# Altium schematic sheet Power.SchDoc(Power)
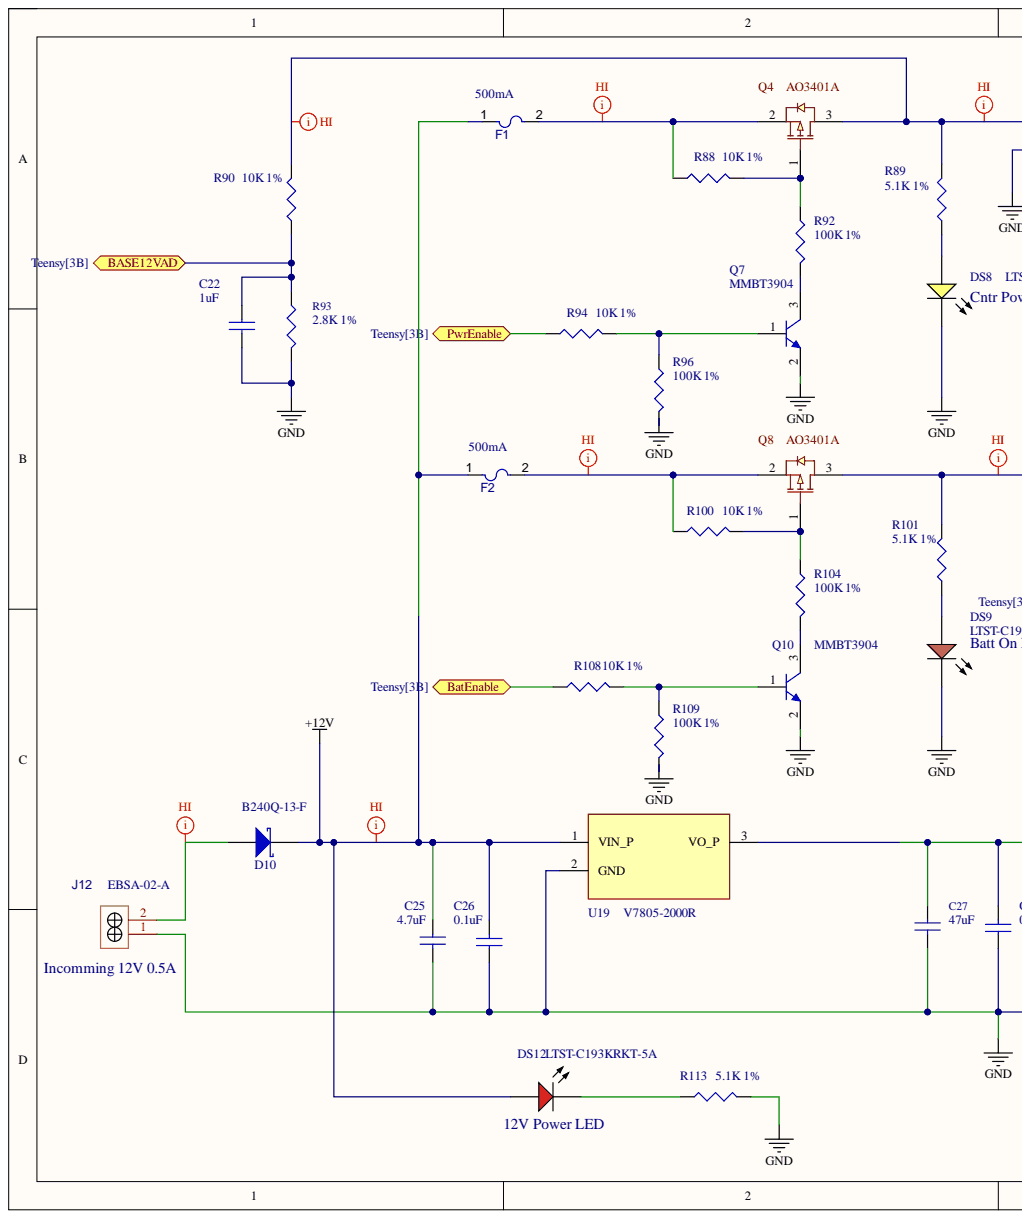
[diagram p0: Power.SchDoc, left]
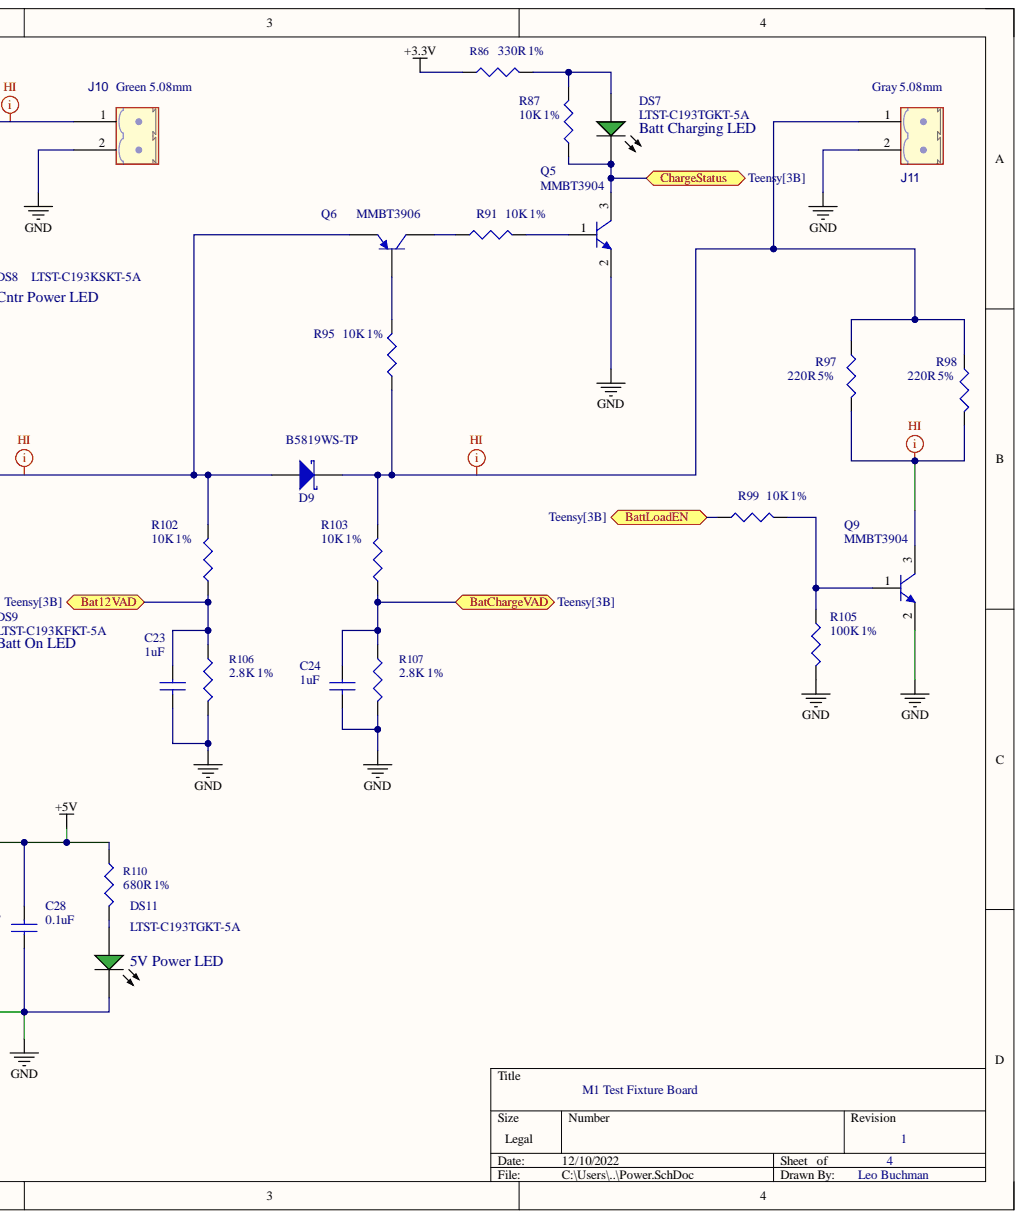
[diagram p1: Power.SchDoc, right]

## Parts
C22  1uF  [C0402]
C23  1uF  [C0402]
C24  1uF  [C0402]
C25  4.7uF  [C0603]
C26  0.1uF  [C0402]
C27  47uF  [C1210]
C28  0.1uF  [C0402]
D9  B5819WS-TP  [MCC-SOD123_V]
D10  B240Q-13-F  [DIODE_DO214AA]
DS7  LTST-C193TGKT-5A  [LED-GREEN_0603]
DS8  LTST-C193KSKT-5A  [LED-YELLOW_0603]
DS9  LTST-C193KFKT-5A  [LED-ORANGE_0603]
DS11  LTST-C193TGKT-5A  Reverse Voltage: 5V  [LED-GREEN_0603]
DS12  LTST-C193KRKT-5A  [LED-RED_0603]
F1  500mA  [FUSE_F050-2]
F2  500mA  [FUSE_F050-2]
J10  Green 5.08mm  Housing Color: Green  [Conn_5433668]
J11  Gray 5.08mm  [Conn_5442002]
J12  EBSA-02-A  [CONN-TH_2P-P3.50_JL15EDGRC-35002G01]
Q4  AO3401A  [AO-SOT-23-3L_V]
Q5  MMBT3904  [sot23-3]
Q6  MMBT3906  [sot23-3]
Q7  MMBT3904  [sot23-3]
Q8  AO3401A  [AO-SOT-23-3L_V]
Q9  MMBT3904  [sot23-3]
Q10  MMBT3904  [sot23-3]
R86  330R 1%  Resistance: 330R  Tolerance: 1%  [R0402]
R87  10K 1%  Tolerance: 1%  [R0402]
R88  10K 1%  [R0402]
R89  5.1K 1%  Tolerance: 1%  [R0402]
R90  10K 1%  Tolerance: 1%  [R0402]
R91  10K 1%  Tolerance: 1%  [R0402]
R92  100K 1%  [R0402]
R93  2.8K 1%  [R0402]
R94  10K 1%  Tolerance: 1%  [R0402]
R95  10K 1%  Tolerance: 1%  [R0402]
R96  100K 1%  [R0402]
R97  220R 5%  Tolerance: 5%  [RESC6331X75N]
R98  220R 5%  Resistance: 220R  Tolerance: 5%  [RESC6331X75N]
R99  10K 1%  Tolerance: 1%  [R0402]
R100  10K 1%  [R0402]
R101  5.1K 1%  Tolerance: 1%  [R0402]
R102  10K 1%  Tolerance: 1%  [R0402]
R103  10K 1%  Tolerance: 1%  [R0402]
R104  100K 1%  [R0402]
R105  100K 1%  [R0402]
R106  2.8K 1%  [R0402]
R107  2.8K 1%  [R0402]
R108  10K 1%  Tolerance: 1%  [R0402]
R109  100K 1%  [R0402]
R110  680R 1%  Resistance: 680R  [R0402]
R113  5.1K 1%  Tolerance: 1%  [R0402]
U19  V7805-2000R  [CONV_V7805-2000R]

## Ports
BASE12VAD, Bat12VAD, BatChargeVAD, BatEnable, BattLoadEN, ChargeStatus, PwrEnable

## Nets
+3.3V: R86.1
+5V: C27.1 C28.2 R110.1 U19.3
+12V: C25.1 C26.2 D10.2 DS12.1 F1.1 F2.1 U19.1
GND: C22.2 C23.2 C24.2 C25.2 C26.1 C27.2 C28.1 DS8.2 DS9.2 DS11.2 J10.2 J11.2 J12.1 Q5.2 Q7.2 Q9.2 Q10.2 R93.2 R96.2 R105.2 R106.2 R107.2 R109.2 R113.2 U19.2
BASE12VAD: C22.1 R90.1 R93.1 ; port BASE12VAD
Bat12VAD: C23.1 R102.1 R106.1 ; port Bat12VAD
BatChargeVAD: C24.1 R103.1 R107.1 ; port BatChargeVAD
BatEnable: R108.1 ; port BatEnable
BattLoadEN: R99.1 ; port BattLoadEN
ChargeStatus: DS7.2 Q5.3 R87.1 ; port ChargeStatus
PwrEnable: R94.1 ; port PwrEnable
NetD9_1: D9.1 Q6.2 Q8.3 R101.2 R102.2
NetD9_2: D9.2 J11.1 R95.1 R97.1 R98.1 R103.2
NetD10_1: D10.1 J12.2
NetDS7_1: DS7.1 R86.2 R87.2
NetDS8_1: DS8.1 R89.1
NetDS9_1: DS9.1 R101.1
NetDS11_1: DS11.1 R110.2
NetDS12_2: DS12.2 R113.1
NetF1_2: F1.2 Q4.2 R88.1
NetF2_2: F2.2 Q8.2 R100.1
NetJ10_1: J10.1 Q4.3 R89.2 R90.2
NetQ4_1: Q4.1 R88.2 R92.1
NetQ5_1: Q5.1 R91.2
NetQ6_1: Q6.1 R95.2
NetQ6_3: Q6.3 R91.1
NetQ7_1: Q7.1 R94.2 R96.1
NetQ7_3: Q7.3 R92.2
NetQ8_1: Q8.1 R100.2 R104.1
NetQ9_1: Q9.1 R99.2 R105.1
NetQ9_3: Q9.3 R97.2 R98.2
NetQ10_1: Q10.1 R108.2 R109.1
NetQ10_3: Q10.3 R104.2

## Library data of the parts
C22-C24 = Cap-00003: CAP CER 1UF 10V Y5V 0402; Manufacturer: KEMET; Manufacturer Part Number: C0402C105Z8VAC7867; Database Table Name: Capacitors; Dielectric Material: Ceramic; Tolerance: 80%; Capacitance: 1uF; Case Code (Imperial): 0402; Case Code (Metric): 1005; Case/Package: 0402; Category: Ceramic Capacitors; Component Kind: Standard; ComponentLink1Description: https://api.kemet.com/component-edge/download; ComponentLink1URL: https://api.kemet.com/component-edge/download; LCSC Part Number: C52923; Max Operating Temperature: 85°C; Min Operating Temperature: -30°C; Mount: Surface Mount; Number of Pins: 2; Packaging: Cut Tape; Part Name: Cap-00003; Pricing 1: 1=0.28000, 10=0.18900, 50=0.12580, 100=0.1070; RoHS: Yes; Stock 1: 9702; Supplier 1: Digi-Key; Supplier Part Number 1: 399-14873-1-ND; Voltage Rating: 10V; Library Name: Leo-Home-SQL-Lib.DbLib
C25 = Cap-00001: CAP CER 4.7UF 16V X5R 0603; Manufacturer: Samsung; Manufacturer Part Number: CL10A475KO8NNNC; Database Table Name: Capacitors; Dielectric Material: Ceramic; Tolerance: 10%; Capacitance: 4.7uF; Case Code (Imperial): 0603; Case Code (Metric): 1608; Case/Package: 0603; Category: Ceramic Capacitors; Component Kind: Standard; ComponentLink1Description: https://datasheet.ciiva.com/25795/cl10a475ko8; ComponentLink1URL: https://datasheet.ciiva.com/25795/cl10a475ko8; ComponentLink2Description: https://datasheet.ciiva.com/26838/getdatashee; ComponentLink2URL: https://datasheet.ciiva.com/26838/getdatashee; ComponentLink3Description: https://datasheet.ciiva.com/29198/457663-da-0; ComponentLink3URL: https://datasheet.ciiva.com/29198/457663-da-0; Construction: Flat; Contact Plating: Tin; Depth: 0.8mm; Dielectric: X5R; Height: 0.8mm; LCSC Part Number: C19666; Length: 1.6mm; Material: Ceramic; Max Operating Temperature: 85°C; Min Operating Temperature: -55°C; Mount: Surface Mount; Number of Pins: 2; Package Quantity: 1; Packaging: Tape and Reel; Part Name: Cap-00001; Pricing 1: 1=0.13000, 10=0.09100, 50=0.05180, 100=0.0429; Radiation Hardening: No; RoHS: Yes; RoHS Compliant: Yes; Series: CL; Stock 1: 1593998; Supplier 1: Digi-Key; Supplier Part Number 1: 1276-1784-1-ND; Thickness: 0.035inch; Voltage: 16V; Voltage Rating: 16V; Width: 0.031inch; Library Name: Leo-Home-SQL-Lib.DbLib
C26 C28 = Cap-00005: Cap Ceramic 0.1uF 50V X7R 10% Pad SMD 0402 125C Automotive T/R; Manufacturer: Murata; Manufacturer Part Number: GRT155R71H104KE01D; Database Table Name: Capacitors; Case Code (Imperial): 0402; Case Code (Metric): 1005; Case/Package: 0402; Category: Ceramic Capacitors; Component Kind: Standard; Dielectric Material: Ceramic; LCSC Part Number: C307331; Mount: Surface Mount; Number of Pins: 2; Part Name: Cap-00005; Pricing 1: 1=0.10000, 10=0.04700, 50=0.02580, 100=0.0211; RoHS: Yes; RoHS Compliant: Yes; Stock 1: 179948; Supplier 1: Digi-Key; Supplier Part Number 1: 490-GRT155R71H104KE01DCT-ND; Voltage Rating (DC): 50V; Library Name: Leo-Home-SQL-Lib.DbLib
C27 = Cap-00004: CAP CER 47UF 16V X5R 1210; Manufacturer: Murata; Manufacturer Part Number: GRM32ER61C476ME15L; Database Table Name: Capacitors; Dielectric Material: Ceramic; Tolerance: 20%; Capacitance: 47uF; Case Code (Imperial): 1210; Case Code (Metric): 3225; Case/Package: 1210; Category: Ceramic Capacitors; Component Kind: Standard; ComponentLink1Description: https://datasheet.ciiva.com/141/product-14197; ComponentLink1URL: https://datasheet.ciiva.com/141/product-14197; ComponentLink2Description: https://datasheet.ciiva.com/14116/grm32er61c4; ComponentLink2URL: https://datasheet.ciiva.com/14116/grm32er61c4; ComponentLink3Description: https://datasheet.ciiva.com/7719/415303-77191; ComponentLink3URL: https://datasheet.ciiva.com/7719/415303-77191; ComponentLink4Description: https://datasheet.ciiva.com/141/partnumbering; ComponentLink4URL: https://datasheet.ciiva.com/141/partnumbering; ComponentLink5Description: https://datasheet.ciiva.com/141/partnumbering; ComponentLink5URL: https://datasheet.ciiva.com/141/partnumbering; ComponentLink6Description: https://datasheet.ciiva.com/16343/c02e-163436; ComponentLink6URL: https://datasheet.ciiva.com/16343/c02e-163436; Depth: 2.5mm; Dielectric: X5R; Height: 2.5mm; LCSC Part Number: C77101; Lead Free: Lead Free; Length: 3.2mm; Max Operating Temperature: 85°C; Min Operating Temperature: -55°C; Mount: Surface Mount; Number of Pins: 2; Package Quantity: 1000; Packaging: Tape and Reel; Part Name: Cap-00004; Pricing 1: 1=0.73000, 10=0.53900, 50=0.43120, 100=0.3697; REACH SVHC: No SVHC; RoHS: Yes; RoHS Compliant: Yes; Series: GRM; Stock 1: 238447; Supplier 1: Digi-Key; Supplier Part Number 1: 490-3888-1-ND; Termination: SMD/SMT; Thickness: 0.106inch; Voltage: 16V; Voltage Rating: 16V; Voltage Rating (DC): 16V; Width: 0.098inch; Library Name: Leo-Home-SQL-Lib.DbLib
D9 = Diode-0002: DIODE SCHOTTKY 40V 1A SOD323; Manufacturer: MCC or Jiangsu Changjing Elec; Manufacturer Part Number: B5819W TP; Color: Blue; Database Table Name: diodes; Dominant Wavelength: 470nm; Forward Current: 25mA; Forward Voltage: 600mV @ 1A; Lens Style: Diffused,Colorless,Rectangular,Clear; Luminous Intensity: 28.5mcd; Number of Elements: 1; Power Dissipation: 110W; Reverse Voltage: 5V; Test Current: 20mA; Viewing Angle: 120°; Average Rectified Current: 1A; Case Code (Imperial): sod123; Case/Package: sod232; Category: Rectifier Diodes; Component Kind: Standard; Component Type: Diodes; ComponentLink1Description: https://datasheet.ciiva.com/16302/19-217-bhc-; ComponentLink1URL: https://datasheet.ciiva.com/16302/19-217-bhc-; ComponentLink2Description: https://datasheet.ciiva.com/26901/getdatashee; ComponentLink2URL: https://datasheet.ciiva.com/26901/getdatashee; Datasheet URL: http://www.mccsemi.com/up_pdf/B5817W-B5819W(SOD-123).pdf; Datasheet Version: Rev. B, 01/2013; Depth: 0.8mm; LCSC Part Number: C84354; Lead Free: Lead Free; Length: 1.6mm; Manufacturer URL: http://www.mccsemi.com/; Max Operating Temperature: 85°C; Max Reverse Voltage (DC): 40V; Min Operating Temperature: -40°C; Mount: Surface Mount; Mounting Technology: SM; Number of LEDs: 1; Package Description: 2-Pin Small Outline Diode, Body 2.7 x 1.6 mm, Height 1.35 mm; Package Reference: SOD123; Package URL: http://www.mccsemi.com/up_pdf/1N4448W(SOD-123).pdf; Packaging: Tape and Reel; Part Name: Diode-0002; Pricing 1: 1=0.47000, 10=0.35200, 100=0.19930, 500=0.132; Radiation Hardening: No; RoHS: Yes; RoHS Compliant: Yes; Stock 1: 237825; Supplier 1: Digi-Key; Supplier Part Number 1: 5819W-TPMSTR-ND; Library Name: Leo-Home-SQL-Lib.DbLib
D10 = Diode-0008: Rectifier Diode Schottky 40V 2A Automotive 2-Pin SMB T/R; Average Rectified Current: 200mA; Capacitance: 10pF; Database Table Name: Diodes; Forward Voltage: 800mV; Max Forward Surge Current (Ifsm): 600mA; Max Power Dissipation: 200mW; Max Repetitive Reverse Voltage (Vrrm): 30V; Max Reverse Current: 2uA; Reverse Recovery Time: 5ns; Case/Package: SMB; Category: Rectifier Diodes; Component Kind: Standard; Component Type: Diodes; ComponentLink1Description: https://datasheet.ciiva.com/34302/1539235-343; ComponentLink1URL: https://datasheet.ciiva.com/34302/1539235-343; ComponentLink2Description: https://datasheet.ciiva.com/22695/1911820-226; ComponentLink2URL: https://datasheet.ciiva.com/22695/1911820-226; ComponentLink3Description: https://datasheet.ciiva.com/15144/getdatashee; ComponentLink3URL: https://datasheet.ciiva.com/15144/getdatashee; Contact Plating: Tin,Matte; Current Rating: 200mA; Datasheet URL: http://www.mccsemi.com/up_pdf/B5817W-B5819W(SOD-123).pdf; Datasheet Version: Rev. B, 01/2013; Forward Current: 200mA; Height: 1mm; LCSC Part Number: C460673; Lead Free: Lead Free; Length: 2.9mm; Manufacturer: Diodes; Manufacturer Part Number: B240Q-13-F; Manufacturer URL: http://www.mccsemi.com/; Max Operating Temperature: 150°C; Max Reverse Voltage (DC): 30V; Min Operating Temperature: -65°C; Mount: Surface Mount; Mounting Technology: SM; Number of Pins: 2; Package Description: 2-Pin Small Outline Diode, Body 2.7 x 1.6 mm, Height 1.35 mm; Package Quantity: 3000; Package Reference: SOD123; Package URL: http://www.mccsemi.com/up_pdf/1N4448W(SOD-123).pdf; Packaging: Tape and Reel; Part Name: Diode-0008; Peak Non-Repetitive Surge Current: 600mA; Power Dissipation: 200mW; Power Rating: 200mW; Pricing 1: 1=0.40000, 10=0.32600, 100=0.22180, 500=0.166; Radiation Hardening: No; REACH SVHC: No SVHC; RoHS: Yes; RoHS Compliant: Yes; Series: -; Stock 1: 9228; Supplier 1: Digi-Key; Supplier Part Number 1: B240Q-13-FDICT-ND; Termination: SMD/SMT; Voltage Rating (DC): 30V; Weight: 0.000282oz; Width: 1.3mm; Library Name: Leo-Home-SQL-Lib.DbLib
DS7 = Diode-0007: LED Green CLEAR 0603 SMD; Manufacturer: Vishay Lite-On; Access Time: 3500 ns; Average Rectified Current: 2A; Case\Package: SOIC; Clock Frequency: 100 KHz; Database Table Name: Diodes; Density: 32 kb; Dominant Wavelength: 535nm; Element Configuration: Single; Forward Current: 5mA; Forward Voltage: 2.0V; Frequency: 400 KHz; Lens Color: Colorless; Lens Style: Diffused,Colorless,Rectangular; Luminous Intensity: 280mcd; Max Repetitive Reverse Voltage (Vrrm): 40V; Max Reverse Current: 500uA; Max Supply Voltage: 5.5 V; Min Supply Voltage: 1.7 V; Nominal Supply Current: 2 mA; Number of Elements: 1; Peak Non-Repetitive Surge Current: 50A; Power Dissipation: 76mW; Reverse Voltage: 5V; Viewing Angle: 130°; Case Code (Imperial): 0603; Case Code (Metric): 1608; Case/Package: 0603; Category: LEDs; Color: Green; Component Kind: Standard; ComponentLink1Description: https://datasheet.ciiva.com/2111/ltst-c193tgk; ComponentLink1URL: https://datasheet.ciiva.com/2111/ltst-c193tgk; ComponentLink2Description: https://datasheet.ciiva.com/11775/0900766b80d; ComponentLink2URL: https://datasheet.ciiva.com/11775/0900766b80d; ComponentLink3Description: https://datasheet.ciiva.com/4689/lite-on-ltst; ComponentLink3URL: https://datasheet.ciiva.com/4689/lite-on-ltst; ComponentLink4Description: https://datasheet.ciiva.com/2111/p-100-ltst-c; ComponentLink4URL: https://datasheet.ciiva.com/2111/p-100-ltst-c; ComponentLink5Description: https://datasheet.ciiva.com/2111/p-100-ltst-c; ComponentLink5URL: https://datasheet.ciiva.com/2111/p-100-ltst-c; ComponentLink6Description: http://www.mouser.com/ds/2/115/B220AQ_Q-B260A; ComponentLink6URL: http://www.mouser.com/ds/2/115/B220AQ_Q-B260A; ComponentLink7Description: https://www.diodes.com/assets/Datasheets/ds13; ComponentLink7URL: https://www.diodes.com/assets/Datasheets/ds13; ComponentLink8Description: http://www.diodes.com/datasheets/ds13004.pdf?; ComponentLink8URL: http://www.diodes.com/datasheets/ds13004.pdf?; Contact Plating: Tin,Matte; DatasheetVersion: Mar-12; Depth: 0.8mm; Height: 0.35mm; Illumination Color: Green; LCSC Part Number: C72043; Lead Free: Lead Free; Length: 1.6mm; Lens Transparency: Clear; Manufacturer Part Number: LTST-C193TGKT-5A; Max Clock Frequency: 100 KHz; Max Operating Temperature: 80°C; Max Reverse Voltage (DC): 40V; Min Operating Temperature: -20°C; Mount: Surface Mount; Number of LEDs: 1; Number of Pins: 2; Package Quantity: 3000; PackageDescription: 2-Pin Surface Mount Device, Body 1.6 x 0.8 mm, Height 0.9 mm; PackageReference: HSMX-C190; Packaging: Tape and Reel; Part Name: Diode-0007; Pins: 8; Pricing 1: 1=0.45000, 10=0.27400, 100=0.15780, 1000=0.12; Radiation Hardening: No; RoHS: Yes; RoHS Compliant: Yes; RoHSCompliant: true; Series: -; Stock 1: 429188; Supplier 1: Digi-Key; Supplier Part Number 1: 160-1832-1-ND; Test Current: 5mA; Width: 0.8mm; Library Name: Leo-Home-SQL-Lib.DbLib
DS8 = Diode-0006: LED Yellow CLEAR 0603 SMD; Manufacturer: Vishay Lite-On; Case\Package: 0603; Database Table Name: Diodes; Dominant Wavelength: 594.5nm; Forward Current: 30mA; Forward Voltage: 2.0V; Lens Color: Colorless; Lens Style: Diffused,Colorless,Rectangular; Luminous Intensity: 45mcd; Number of Elements: 1; Power Dissipation: 75mW; Resistance: 390 Ohm; Reverse Voltage: 5V; Test Current: 5mA; Viewing Angle: 130°; Voltage Rating: 50 V; Case Code (Imperial): 0603; Case Code (Metric): 1608; Case/Package: 0603; Category: LEDs; Color: Yellow; Component Kind: Standard; ComponentLink1Description: https://datasheet.ciiva.com/11775/0900766b80d; ComponentLink1URL: https://datasheet.ciiva.com/11775/0900766b80d; ComponentLink2Description: https://datasheet.ciiva.com/4688/lite-on-ltst; ComponentLink2URL: https://datasheet.ciiva.com/4688/lite-on-ltst; ComponentLink3Description: https://datasheet.ciiva.com/26890/getdatashee; ComponentLink3URL: https://datasheet.ciiva.com/26890/getdatashee; ComponentLink4Description: https://datasheet.ciiva.com/2110/s-110-ltst-c; ComponentLink4URL: https://datasheet.ciiva.com/2110/s-110-ltst-c; ComponentLink5Description: https://datasheet.ciiva.com/2110/s-110-ltst-c; ComponentLink5URL: https://datasheet.ciiva.com/2110/s-110-ltst-c; ComponentLink6Description: https://datasheet.ciiva.com/2110/s-110-ltst-c; ComponentLink6URL: https://datasheet.ciiva.com/2110/s-110-ltst-c; ComponentLink7Description: https://datasheet.ciiva.com/2110/ltst-c193kfk; ComponentLink7URL: https://datasheet.ciiva.com/2110/ltst-c193kfk; DatasheetVersion: Mar-12; Depth: 0.8mm; Height: 0.35mm; Illumination Color: Yellow; LCSC Part Number: C72038; Lead Free: Lead Free; Length: 1.6mm; Lens Transparency: Clear; Manufacturer Part Number: LTST-C193KSKT-5A; Max Operating Temperature: 85°C; Min Operating Temperature: -55°C; Mount: Surface Mount; Number of LEDs: 1; Number of Pins: 2; Package Quantity: 1; PackageDescription: 2-Pin Surface Mount Device, Body 1.6 x 0.8 mm, Height 0.9 mm; PackageReference: HSMX-C190; Packaging: Tape and Reel; Part Name: Diode-0006; Pins: 2; Power Rating: 75mW; Pricing 1: 1=0.39000, 10=0.20900, 100=0.09720, 500=0.089; Radiation Hardening: No; RoHS: Yes; RoHS Compliant: Yes; RoHSCompliant: true; Stock 1: 147319; Supplier 1: Digi-Key; Supplier Part Number 1: 160-1831-1-ND; Width: 0.8mm; Library Name: Leo-Home-SQL-Lib.DbLib
DS9 = Diode-0004: LED Orange CLEAR 0603 SMD; Manufacturer: Vishay Lite-On; Database Table Name: Diodes; Dominant Wavelength: 605nm; Forward (Drive) Current: 20mA; Forward Current: 5mA; Forward Voltage: 2.0V; Intensity: 22mcd; Lens Color: Colorless; Lens Style: Diffused,Colorless,Rectangular,Clear; Luminous Intensity: 28.1mcd; Number of Elements: 1; Viewing Angle: 130°; Case Code (Imperial): 0603; Case Code (Metric): 1608; Case/Package: 0603; Category: LEDs; Color: Orange; Component Kind: Standard; Datasheet URL: https://datasheet.ciiva.com/11773/0900766b80df3ad8-11773825.pdf; Datasheet Version: Rev. 2.0, 03/2010; Dimension: 1.6x0.8x0.36mm; Height: 0.35mm; Illumination Color: Orange; LCSC Part Number: C434425; Lead Free: Lead Free; Lens Transparency: Clear; Lens Type: Clear; Manufacturer Part Number: LTST-C193KFKT-5A; Manufacturer URL: http://www.rohm.com/web/global/; Max Operating Temperature: 85°C; Min Operating Temperature: -55°C; Mount: Surface Mount; Mounting Technology: SurfaceMount; Number of Pins: 2; Package Description: 2-Pin Surface Mount Device, Body 1.6 x 0.8 mm; Package Reference: 1608; Packaging: Tape and Reel; Part Name: Diode-0004; Pricing 1: 1=0.39000, 10=0.20900, 100=0.09720, 500=0.089; Radiation Hardening: No; RoHS: Yes; RoHS Compliant: Yes; Stock 1: 55639; Supplier 1: Digi-Key; Supplier Part Number 1: 160-1829-1-ND; Width: 0.8mm; Library Name: Leo-Home-SQL-Lib.DbLib
DS11 = Diode-0007: LED Green CLEAR 0603 SMD; Manufacturer: Vishay Lite-On; Access Time: 3500 ns; Average Rectified Current: 2A; Case\Package: SOIC; Clock Frequency: 100 KHz; Database Table Name: Diodes; Density: 32 kb; Dominant Wavelength: 535nm; Element Configuration: Single; Forward Current: 5mA; Forward Voltage: 2.0V; Frequency: 400 KHz; Lens Color: Colorless; Lens Style: Diffused,Colorless,Rectangular; Luminous Intensity: 280mcd; Max Repetitive Reverse Voltage (Vrrm): 40V; Max Reverse Current: 500uA; Max Supply Voltage: 5.5 V; Min Supply Voltage: 1.7 V; Nominal Supply Current: 2 mA; Number of Elements: 1; Peak Non-Repetitive Surge Current: 50A; Power Dissipation: 76mW; Viewing Angle: 130°; Case Code (Imperial): 0603; Case Code (Metric): 1608; Case/Package: 0603; Category: LEDs; Color: Green; Component Kind: Standard; ComponentLink1Description: https://datasheet.ciiva.com/2111/ltst-c193tgk; ComponentLink1URL: https://datasheet.ciiva.com/2111/ltst-c193tgk; ComponentLink2Description: https://datasheet.ciiva.com/11775/0900766b80d; ComponentLink2URL: https://datasheet.ciiva.com/11775/0900766b80d; ComponentLink3Description: https://datasheet.ciiva.com/4689/lite-on-ltst; ComponentLink3URL: https://datasheet.ciiva.com/4689/lite-on-ltst; ComponentLink4Description: https://datasheet.ciiva.com/2111/p-100-ltst-c; ComponentLink4URL: https://datasheet.ciiva.com/2111/p-100-ltst-c; ComponentLink5Description: https://datasheet.ciiva.com/2111/p-100-ltst-c; ComponentLink5URL: https://datasheet.ciiva.com/2111/p-100-ltst-c; ComponentLink6Description: http://www.mouser.com/ds/2/115/B220AQ_Q-B260A; ComponentLink6URL: http://www.mouser.com/ds/2/115/B220AQ_Q-B260A; ComponentLink7Description: https://www.diodes.com/assets/Datasheets/ds13; ComponentLink7URL: https://www.diodes.com/assets/Datasheets/ds13; ComponentLink8Description: http://www.diodes.com/datasheets/ds13004.pdf?; ComponentLink8URL: http://www.diodes.com/datasheets/ds13004.pdf?; Contact Plating: Tin,Matte; DatasheetVersion: Mar-12; Depth: 0.8mm; Height: 0.35mm; Illumination Color: Green; LCSC Part Number: C72043; Lead Free: Lead Free; Length: 1.6mm; Lens Transparency: Clear; Manufacturer Part Number: LTST-C193TGKT-5A; Max Clock Frequency: 100 KHz; Max Operating Temperature: 80°C; Max Reverse Voltage (DC): 40V; Min Operating Temperature: -20°C; Mount: Surface Mount; Number of LEDs: 1; Number of Pins: 2; Package Quantity: 3000; PackageDescription: 2-Pin Surface Mount Device, Body 1.6 x 0.8 mm, Height 0.9 mm; PackageReference: HSMX-C190; Packaging: Tape and Reel; Part Name: Diode-0007; Pins: 8; Pricing 1: 1=0.45000, 10=0.27400, 100=0.15780, 1000=0.12; Radiation Hardening: No; RoHS: Yes; RoHS Compliant: Yes; RoHSCompliant: true; Series: -; Stock 1: 429188; Supplier 1: Digi-Key; Supplier Part Number 1: 160-1832-1-ND; Test Current: 5mA; Width: 0.8mm; Library Name: Leo-Home-SQL-Lib.DbLib
DS12 = Diode-0005: LED RED CLEAR 0603 SMD; Manufacturer: Vishay Lite-On; Chip Material: GaAlAs; Database Table Name: Diodes; DELETED: Compliant; Dominant Wave Length: 639nm; Dominant Wavelength: 631nm; Forward Current: 5mA; Forward Voltage: 2.0V; High Brightness: No; Lens Color: Colorless; Lens Style: Diffused,Colorless,Clear,Rectangular; Lens Type: ColorlessDiffused; Lum Intensity: 17mcd; Luminous Intensity: 45mcd; Main Category: ChipLED; Number of  Elements: 1; Peak Wavelength: 660nm; Power Dissipation: 50mW; Product Depth (mm): 1.6mm; Product Height (mm): 1.1mm; Product Length (mm): 3.2mm; Reverse Voltage: 5V; Shape Type: Rectangular; Test Current (It): 20mA; Type: Uni-Color; Viewing Angle: 130°; Case Code (Imperial): 0603; Case Code (Metric): 1608; Case/Package: 0603; Category: LEDs; Color: Red; Component Kind: Standard; ComponentLink1Description: https://datasheet.ciiva.com/11775/0900766b80d; ComponentLink1URL: https://datasheet.ciiva.com/11775/0900766b80d; ComponentLink2Description: https://datasheet.ciiva.com/4689/100-ltst-c19; ComponentLink2URL: https://datasheet.ciiva.com/4689/100-ltst-c19; ComponentLink3Description: https://datasheet.ciiva.com/16210/ltst-c193kr; ComponentLink3URL: https://datasheet.ciiva.com/16210/ltst-c193kr; ComponentLink4Description: https://datasheet.ciiva.com/2110/s-110-ltst-c; ComponentLink4URL: https://datasheet.ciiva.com/2110/s-110-ltst-c; Datasheet URL: http://media.digikey.com/pdf/Data%20Sheets/Avago%20PDFs/HSMz-Czzz.pdf; Datasheet Version: 03/2012; Depth: 0.8mm; Emitting Color: Red; Height: 0.35mm; Illumination Color: Red; LCSC Part Number: C2286; Lead Free: Lead Free; Length: 1.6mm; Lens Dimensions: 2x1.6x0.6mm; Lens Transparency: Clear; Manufacturer Part Number: LTST-C193KRKT-5A; Manufacturer URL: http://www.avagotech.com/; Max Operating Temperature: 85°C; Min Operating Temperature: -55°C; Mount: Surface Mount; Mounting: SurfaceMount; Mounting Technology: SurfaceMount; Number of Elements: 1; Number of LEDs: 1; Number of Pins: 2; Operating Temp Range: -40Cto85C; Operating Temperature Classification: Industrial; Package Description: 2-Pin Surface Mount Device, Body 3.2 x 1.6 mm; Package Quantity: 3000; Package Reference: HSMX-C150; Package Type: ChipLed; Packaging: Tape and Reel; Part Name: Diode-0005; Pin Count: 2; Pricing 1: 1=0.39000, 10=0.20900, 100=0.09720, 500=0.089; Rad Hardened: No; Radiation Hardening: No; RoHS: Yes; RoHS Compliant: Yes; Series: -; Stock 1: 476214; Supplier 1: Digi-Key; Supplier Part Number 1: 160-1830-1-ND; Test Current: 5mA; Width: 0.8mm; Library Name: Leo-Home-SQL-Lib.DbLib
F1 F2 = MF-MSMF050-2: 15VDC 500mA; Manufacturer: Bourns; Aging: 1000 hr @ +85 °C (Passive); Approvals: UL,CSA,TUV; Current Rating: 100A; Database Table Name: Fuses; Number of Terminals/Pins: 2; Operating Supply Voltage: 15V; Power Dissipation: 800mW; Resistance: 150mR; Time to Trip: 0.15s; Trip Current: 1A; Case Code (Imperial): 1812; Case Code (Metric): 4532; Case/Package: 1812; Category: PTC Resettable Fuses; Component Kind: Standard; Component Type: Fuses; ComponentLink1Description: https://datasheet.ciiva.com/34302/1164200-343; ComponentLink1URL: https://datasheet.ciiva.com/34302/1164200-343; ComponentLink2Description: https://datasheet.ciiva.com/1228/1786007-1228; ComponentLink2URL: https://datasheet.ciiva.com/1228/1786007-1228; ComponentLink3Description: https://datasheet.ciiva.com/32426/mf-msmf-ser; ComponentLink3URL: https://datasheet.ciiva.com/32426/mf-msmf-ser; ComponentLink4Description: https://datasheet.ciiva.com/22749/1695373-227; ComponentLink4URL: https://datasheet.ciiva.com/22749/1695373-227; ComponentLink5Description: https://datasheet.ciiva.com/46395/1695373-463; ComponentLink5URL: https://datasheet.ciiva.com/46395/1695373-463; ComponentLink6Description: https://datasheet.ciiva.com/4501/mfmsmf-72300; ComponentLink6URL: https://datasheet.ciiva.com/4501/mfmsmf-72300; ComponentLink7Description: https://datasheet.ciiva.com/14537/70153434-14; ComponentLink7URL: https://datasheet.ciiva.com/14537/70153434-14; ComponentLink8Description: https://datasheet.ciiva.com/1274/1786007-1274; ComponentLink8URL: https://datasheet.ciiva.com/1274/1786007-1274; ComponentLink9Description: https://datasheet.ciiva.com/1274/1786007-1274; ComponentLink9URL: https://datasheet.ciiva.com/1274/1786007-1274; ComponentLink10Description: https://datasheet.ciiva.com/3019/mfmsmf-30196; ComponentLink10URL: https://datasheet.ciiva.com/3019/mfmsmf-30196; ComponentLink11Description: https://datasheet.ciiva.com/16205/mfmsmf-1620; ComponentLink11URL: https://datasheet.ciiva.com/16205/mfmsmf-1620; ComponentLink12Description: https://datasheet.ciiva.com/15226/getdatashee; ComponentLink12URL: https://datasheet.ciiva.com/15226/getdatashee; ComponentLink13Description: https://datasheet.ciiva.com/3019/mfmsmf-30196; ComponentLink13URL: https://datasheet.ciiva.com/3019/mfmsmf-30196; ComponentLink14Description: https://datasheet.ciiva.com/326/mfmsmf-326355; ComponentLink14URL: https://datasheet.ciiva.com/326/mfmsmf-326355; ComponentLink15Description: https://datasheet.ciiva.com/326/mfmsmf-326356; ComponentLink15URL: https://datasheet.ciiva.com/326/mfmsmf-326356; ComponentLink16Description: https://datasheet.ciiva.com/326/mfmsmf-326354; ComponentLink16URL: https://datasheet.ciiva.com/326/mfmsmf-326354; Contact Plating: Gold; Datasheet URL: http://www.bourns.com/data/global/pdfs/mfmsmf.pdf; Datasheet Version: Rev. AG, 08/2016; Height: 0.85mm; Hold Current: 500mA; LCSC Part Number: C17313; Lead Free: Lead Free; Length: 4.73mm; Manufacturer Part Number: MF-MSMF050-2; Max Current Rating: 100A; Max Operating Temperature: 85°C; Min Operating Temperature: -40°C; Mount: Surface Mount,PCB; Mounting Technology: SM; Number of Pins: 2; Package Description: 2-Pin Surface Mount Device, Body 4.55 x 3.24 mm; Package Quantity: 2000; Package Reference: MF-MSMF050-2; Packaging: Tape and Reel; Part Name: MF-MSMF050-2; Pricing 1: 1=0.32000, 5=0.31000, 10=0.29500, 50=0.26360,; Radiation Hardening: No; REACH SVHC: No SVHC; RoHS: Yes; RoHS Compliant: Yes; Series: MF-MSMF; Stock 1: 59686; Supplier 1: Digi-Key; Supplier Part Number 1: MF-MSMF050-2CT-ND; Terminal Material: Gold; Termination: SMD/SMT; Voltage Rating: 15V; Voltage Rating (DC): 15V; Width: 3.41mm; Library Name: Leo-Home-SQL-Lib.DbLib
J10 = CON-0006: Pluggable Term Blocks BCH-508HS- 2 GN 5.08; Manufacturer: Phoenix Contact; Manufacturer Part Number: 5442002; Approvals: UL,CSA,cULus,CB,EAC; ATTR: HEADER TERM BLK 5.08MM 2POS RTA GRAY; Connector Type: Screw; Current: 13.5A; Database Table Name: Connectors; Gender: Male; Number of Circuits: 3; Number of Levels: 1; Pitch: 3.5mm; PKG: TB508HDR02_RTA; Stripping Length: 5mm; Voltage: 300V; Voltage Rating: 200V; Wire Gauge (Max): 30AWG; Wire Gauge (Min): 16AWG; Category: Terminal Blocks; Component Kind: Standard; ComponentLink1Description: https://datasheet.ciiva.com/16046/1751251-160; ComponentLink1URL: https://datasheet.ciiva.com/16046/1751251-160; ComponentLink2Description: https://datasheet.ciiva.com/6745/1751251-6745; ComponentLink2URL: https://datasheet.ciiva.com/6745/1751251-6745; ComponentLink3Description: https://datasheet.ciiva.com/29226/774163-da-0; ComponentLink3URL: https://datasheet.ciiva.com/29226/774163-da-0; Contact Material: Tin; Current Rating: 13.5A; Flammability Rating: UL94 V-0; Height: 11mm; Insulation Material: Nylon; LCSC Part Number: N/A; Lead Free: Lead Free; Length: 7.3mm; Material: Polyamide; Max Current Rating: 13.5A; Mount: Through Hole; Number of Contacts: 3; Number of Poles: 3; Number of Positions: 3; Number of Positions Per Level: 3; Number of Rows: 1; Orientation: Straight; Package Quantity: 50; Packaging: Bulk; Part Name: CON-0006; Pricing 1: 1=0.68000, 10=0.64900, 50=0.63140, 100=0.5900; REACH SVHC: Unknown; RoHS: Yes; RoHS Compliant: Yes; Series: COMBICON MKDS; Stock 1: 219; Supplier 1: Digi-Key; Supplier Part Number 1: 277-11311-ND; Termination: Screw; Weight: 0.056791oz; Width: 12mm; Wire/Cable Gauge: 30AWG; Library Name: Leo-Home-SQL-Lib.DbLib
J11 = CON-0005: Pluggable Term Blocks BCH-508HS- 2 GR 5.08; Manufacturer: Phoenix Contact; Manufacturer Part Number: 5433668; ATTR: HEADER TERM BLK 5.08MM 2POS RTA GREEN; Current Rating: 12A; Database Table Name: Connectors; Number of Levels: 1; Number of Positions Per Level: 2; PKG: TB508HDR02_RTA; Voltage Rating: 320V; Category: Terminal Blocks; Color: Grey; Component Kind: Standard; Contact Plating: Tin; LCSC Part Number: N/A; Mount: Through Hole; Package Quantity: 100; Packaging: Bulk; Part Name: CON-0005; Pricing 1: 1=0.69000, 10=0.66000, 50=0.64200, 100=0.6000; RoHS: Yes; Stock 1: 100; Supplier 1: Digi-Key; Supplier Part Number 1: 277-11218-ND; Termination: Solder; Library Name: Leo-Home-SQL-Lib.DbLib
J12 = CON-0001: Conn Header Right angle 3.5 pitch 2P; Manufacturer: Adam Equipment; Connector Type: Male Pin; Database Table Name: Connectors; Number of Positions: 6; Pitch: 0.1inch; Category: Connectors; Color: Black; Component Kind: Standard; ComponentLink1Description: https://datasheet.ciiva.com/741/xrxczzzsxxn-r; ComponentLink1URL: https://datasheet.ciiva.com/741/xrxczzzsxxn-r; Contact Mating Length: 0.318inch; Contact Plating: Gold; Contact Type: Male Pin; LCSC Part Number: C409115; Manufacturer Part Number: EBSA-02-A; Mount: Through Hole; Number of Rows: 1; Package Quantity: 1; Packaging: Bulk; Part Name: CON-0001; Pricing 1: 1=0.58000, 10=0.31600, 25=0.23600, 50=0.21040; Pricing 2: 1=0.21000, 10=0.19800, 25=0.16920, 50=0.14820; RoHS: Yes; RoHS Compliant: Yes; Series: -; Stock 1: 2090; Stock 2: 1241; Supplier 1: Digi-Key; Supplier 2: Digi-Key; Supplier Part Number 1: 2057-EBSA-02-A-ND; Supplier Part Number 2: S1211EC-06-ND; Termination: Solder; Library Name: Leo-Home-SQL-Lib.DbLib
Q4 Q8 = Tran-0001: Mosfet P-channel 30V 4A SOT23-3; Manufacturer: Alpha & Omega Semiconductor; Manufacturer Part Number: AO3401AL; Channel Mode: Enhancement; Collector Base Voltage (VCBO): 60V; Collector Emitter Breakdown Voltage: 40V; Collector Emitter Saturation Voltage: 300mV; Current Rating: 200mA; Database Table Name: Transistors; Drain Efficiency: NotRequired%; Drain-Source On-Res: 0.044ohm; Element Configuration: Single; Emitter Base Voltage (VEBO): 6V; Frequency: 300MHz; Frequency (Max): NotRequiredMHz; Gate-Source Voltage (Max): ?12V; hFE Min: 100; Input Capacitance: 645pF; Max Power Dissipation: 1.4W; Noise Figure: NotRequireddB; Number of  Elements: 1; Output Power (Max): NotRequiredW; Polarity: NPN; Power Dissipation: 350mW; Rad Hardened: No; Rds On Max: 50mR; Type: PowerMOSFET; Case/Package: SOT-23-3; Category: BJTs; Collector Emitter Voltage (VCEO): 40V; Component Kind: Standard; ComponentLink1Description: https://media.digikey.com/pdf/Data%20Sheets/A; ComponentLink1URL: https://media.digikey.com/pdf/Data%20Sheets/A; ComponentLink2Description: https://datasheet.ciiva.com/7972/2n3904-79726; ComponentLink2URL: https://datasheet.ciiva.com/7972/2n3904-79726; ComponentLink3Description: https://datasheet.ciiva.com/22653/1922793-226; ComponentLink3URL: https://datasheet.ciiva.com/22653/1922793-226; ComponentLink4Description: https://datasheet.ciiva.com/11201/mmbt3904-11; ComponentLink4URL: https://datasheet.ciiva.com/11201/mmbt3904-11; ComponentLink5Description: https://datasheet.ciiva.com/16850/mmbt3904-16; ComponentLink5URL: https://datasheet.ciiva.com/16850/mmbt3904-16; ComponentLink6Description: https://datasheet.ciiva.com/971/mmbt3904-9718; ComponentLink6URL: https://datasheet.ciiva.com/971/mmbt3904-9718; ComponentLink7Description: https://datasheet.ciiva.com/971/mmbt3904-9718; ComponentLink7URL: https://datasheet.ciiva.com/971/mmbt3904-9718; ComponentLink8Description: https://datasheet.ciiva.com/971/mmbt3904-9718; ComponentLink8URL: https://datasheet.ciiva.com/971/mmbt3904-9718; Contact Plating: Tin,Matte; Continuous Drain Current: 4A; Continuous Drain Current (ID): 4A; Datasheet URL: http://www.aosmd.com/res/data_sheets/AO3401A.pdf; Datasheet Version: Rev. 3, 03/2011; Drain Current (Max): 4A; Drain to Source Voltage (Vdss): 30V; Drain-Source On-Volt: 30V; Gain Bandwidth Product: 300MHz; Height: 0.25inch; LCSC Part Number: C15127; Lead Free: Lead Free; Length: 0.25inch; Manufacturer URL: http://www.aosmd.com/; Max Breakdown Voltage: 40V; Max Collector Current: 200mA; Max Frequency: 300MHz; Max Operating Temperature: 150°C; Min Operating Temperature: -55°C; Mount: Surface Mount; Mounting: SurfaceMount; Mounting Technology: SurfaceMount; Number of Elements: 1; Number of Pins: 3; Operating Temp Range: -55Cto150C; Operating Temperature Classification: Military; Package Description: 3-Pin Small Outline Transistor, Body 2.95 x 1.6 mm, Pitch 0.95 mm; Package Quantity: 1; Package Reference: SOT-23-3L; Package Type: SOT-23; Package URL: http://www.aosmd.com/res/packaging_information/SOT-23-3L.pdf; Packaging: Tape and Reel; Part Name: Tran-0001; Pin Count: 3; Power Gain: NotRequireddB; Pricing 1: 1=0.03200, 10=0.02500, 100=0.01700, 500=0.017; Radiation Hardening: No; REACH SVHC: No SVHC; RoHS: Yes; RoHS Compliant: Yes; Supplier 1: Digi-Key; Supplier Part Number 1: AO3401AL-ND; Termination: SMD/SMT; Transition Frequency: 300MHz; Voltage Rating (DC): 40V; Weight: 0.03g; Width: 0.25inch; Library Name: Leo-Home-SQL-Lib.DbLib
Q5 Q7 Q9 Q10 = Tran-0002: Trans GP BJT NPN 60V 0.2A 350mW Automotive 3-Pin SOT-23 T/R; Manufacturer: ON Semiconductor / Fairchild; Collector-emitter Voltage-Max: 400mV; Database Table Name: Transistors; Elements: 1; Frequency: 250MHz; Max Collector Current: 200mA; Power: 225mW; Vce Max: 300mV; Case/Package: SOT-23-3; Component Kind: Standard; Component Type: Transistors; ComponentLink1Description: https://datasheet.ciiva.com/22664/1708270-226; ComponentLink1URL: https://datasheet.ciiva.com/22664/1708270-226; ComponentLink2Description: https://datasheet.ciiva.com/4721/mmbt3906lt1-; ComponentLink2URL: https://datasheet.ciiva.com/4721/mmbt3906lt1-; ComponentLink3Description: https://datasheet.ciiva.com/11201/mmbt3906lt1; ComponentLink3URL: https://datasheet.ciiva.com/11201/mmbt3906lt1; ComponentLink4Description: https://datasheet.ciiva.com/16387/mmbt3906lt1; ComponentLink4URL: https://datasheet.ciiva.com/16387/mmbt3906lt1; ComponentLink5Description: https://datasheet.ciiva.com/976/mmbt3906lt1-d; ComponentLink5URL: https://datasheet.ciiva.com/976/mmbt3906lt1-d; ComponentLink6Description: https://datasheet.ciiva.com/976/mmbt3906lt1-d; ComponentLink6URL: https://datasheet.ciiva.com/976/mmbt3906lt1-d; ComponentLink7Description: https://datasheet.ciiva.com/976/mmbt3906lt1-d; ComponentLink7URL: https://datasheet.ciiva.com/976/mmbt3906lt1-d; Datasheet URL: http://www.onsemi.cn/pub_link/Collateral/MMBT3904LT1-D.PDF; Datasheet Version: Rev. 12, 11/2012; Height: 0.94mm; LCSC Part Number: C20526; Lead Free: Lead Free; Length: 2.9mm; Manufacturer Part Number: MMBT3904; Max Frequency: 250MHz; Max Operating Temperature: 150°C; Min Operating Temperature: -55°C; Mount: Surface Mount; Mounting Technology: SM; Number of Elements: 1; Package Description: 3-Pin Small Outline Transistor, Body 2.9 x 1.3 mm, Pitch 0.95 mm; Package Reference: SOT-23 (318-08); Part Name: Tran-0002; Pins: 3; Polarity: NPN; Radiation Hardening: No; REACH SVHC: No SVHC; RoHS: Yes; RoHS Compliant: Yes; Series: -; Supplier 1: Newark; Supplier Part Number 1: 58K9431; Width: 1.3mm; Library Name: Leo-Home-SQL-Lib.DbLib
Q6 = Tran-0003: PNP Ic=-200mA Vceo=-40V hfe=100~300 P=225mW SOT-23; Manufacturer: ON Semiconductor; Manufacturer Part Number: MMBT3906LT1G; Collector Base Voltage (VCBO): 40V; Collector Emitter Saturation Voltage: -400mV; Current Rating: -200mA; Database Table Name: Transistors; Element Configuration: Single; Elements: 1; Emitter Base Voltage (VEBO): 5V; Gain Bandwidth Product: 250MHz; hFE Min: 60; Max Collector Current: 200mA; Max Power Dissipation: 300mW; Power: 225mW; Vce Max: 400mV; Voltage Rating (DC): -40V; Case/Package: SOT-23-3; Category: BJTs; Collector Emitter Breakdown Voltage: 40V; Collector Emitter Voltage (VCEO): 40V; Component Kind: Standard; Component Type: Transistors; Contact Plating: Tin,Matte; Datasheet URL: http://www.onsemi.cn/pub_link/Collateral/MMBT3906LT1-D.PDF; Datasheet Version: Rev. 12, 10/2016; LCSC Part Number: C2143; Max Breakdown Voltage: 40V; Max Operating Temperature: 150°C; Min Operating Temperature: -55°C; Mount: Surface Mount; Mounting Technology: SM; Number of Pins: 3; Package Description: 3-Pin Small Outline Transistor, Body 2.9 x 1.3 mm, Pitch 0.95 mm; Package Quantity: 1; Package Reference: SOT-23 (318-08); Packaging: Tape and Reel; Part Name: Tran-0003; Pins: 3; Polarity: PNP; Power Dissipation: 300mW; Pricing 1: 1=0.15000, 10=0.14600, 50=0.14600, 100=0.0790; RoHS: Yes; Supplier 1: Mouser; Supplier Part Number 1: 863-MMBT3906LT1G; Transition Frequency: 250MHz; Library Name: Leo-Home-SQL-Lib.DbLib
R86 = Res-00009: Thick Film 0402 330 Ohm 1% 1/16W ±100ppm/°C Molded SMD Paper T/R; Manufacturer: Yageo; Manufacturer Part Number: AF0402FR-07330RL; Database Table Name: Resistors; Max Power Dissipation: 63mW; Power Rating: 62.5mW; Case Code (Imperial): 0402; Case Code (Metric): 1005; Case/Package: 0402; Category: Chip SMD Resistors; Component Kind: Standard; ComponentLink1Description: http://www.yageo.com/documents/recent/PYu-AF_; ComponentLink1URL: http://www.yageo.com/documents/recent/PYu-AF_; Features: Moisture Resistant,Anti-Sulfur; Height: 0.015inch; LCSC Part Number: C51721; Length: 0.039inch; Max Operating Temperature: 155°C; Min Operating Temperature: -55°C; Mount: Surface Mount; Number of Terminations: 2; Packaging: Tape and Reel; Part Name: Res-00009; Pricing 1: 1=0.10000, 10=0.05800, 100=0.02360, 1000=0.01; Radiation Hardening: No; Resistor Type: Thick Film; RoHS: Yes; RoHS Compliant: Yes; Stock 1: 15948; Supplier 1: Digi-Key; Supplier Part Number 1: 13-AF0402FR-07330RLCT-ND; Temperature Coefficient: 100ppm/°C; Width: 0.02inch; Library Name: Leo-Home-SQL-Lib.DbLib
R87 R88 R90 R91 R94 R95 R99 R100 R102 R103 R108 = Res-00002: Thick Film 0402 10K OHM 1% 1/16W 0402; Manufacturer: Yageo; Manufacturer Part Number: RC0402FR-0710KP; Database Table Name: Resistors; Max Power Dissipation: 63mW; Power Rating: 62.5mW; Temperature Coefficient: 150ppm/°C; Case Code (Imperial): 0402; Case Code (Metric): 1005; Case/Package: 0402; Category: Chip SMD Resistors; Component Kind: Standard; ComponentLink1Description: http://www.yageo.com/NewPortal/yageodocoutput; ComponentLink1URL: http://www.yageo.com/NewPortal/yageodocoutput; Features: Moisture Resistant; Height: 0.016inch; LCSC Part Number: C25744; Length: 0.039inch; Max Operating Temperature: 155°C; Min Operating Temperature: -55°C; Mount: Surface Mount; Number of Terminations: 2; Packaging: Tape and Reel; Part Name: Res-00002; Pricing 1: 1=0.10000, 10=0.03600, 100=0.01450, 1000=0.00; Resistance: 10k; Resistor Type: Thick Film; RoHS: Yes; RoHS Compliant: Yes; Stock 1: 161500; Supplier 1: Digi-Key; Supplier Part Number 1: YAG1249CT-ND; Width: 0.02inch; Library Name: Leo-Home-SQL-Lib.DbLib
  R88 R100: Tolerance: 1%
R89 R101 R113 = Res-00004: Thick Film 0402 5.1K Ohm 1% 1/16W 0402; Manufacturer: Stackpole Electronics; Manufacturer Part Number: RMCF0402FT5K10; Database Table Name: Resistors; Max Power Dissipation: 63mW; Power Rating: 62.5mW; Temperature Coefficient: 100ppm/°C; Case Code (Imperial): 0402; Case Code (Metric): 1005; Case/Package: 0402; Category: Chip SMD Resistors; Component Kind: Standard; ComponentLink1Description: http://components.arrow.com/part/dataview/513; ComponentLink1URL: http://components.arrow.com/part/dataview/513; ComponentLink2Description: https://www.seielect.com/catalog/SEI-RMCF_RMC; ComponentLink2URL: https://www.seielect.com/catalog/SEI-RMCF_RMC; ComponentLink3Description: https://www.seielect.com/catalog/SEI-Packagin; ComponentLink3URL: https://www.seielect.com/catalog/SEI-Packagin; ComponentLink4Description: https://datasheet.ciiva.com/15193/getdatashee; ComponentLink4URL: https://datasheet.ciiva.com/15193/getdatashee; Construction: Rectangular; Contact Plating: Tin,Matte; Depth: 0.5mm; Features: Automotive AEC-Q200; Height: 0.016inch; LCSC Part Number: C25905; Lead Free: Lead Free; Length: 0.039inch; Max Operating Temperature: 155°C; Military Standard: Not; Min Operating Temperature: -55°C; Mount: Surface Mount; Number of Terminations: 2; Packaging: Tape and Reel; Part Name: Res-00004; Pricing 1: 1=0.10000, 10=0.01500, 100=0.00610, 1000=0.00; Radiation Hardening: No; Resistance: 5.1k; Resistor Type: Thick Film; RoHS: Yes; RoHS Compliant: Yes; Series: RMCF; Size Code: 0402; Stock 1: 131490; Supplier 1: Digi-Key; Supplier Part Number 1: RMCF0402FT5K10CT-ND; Width: 0.02inch; Library Name: Leo-Home-SQL-Lib.DbLib
R92 R96 R104 R105 R109 = Res-00003: Thick Film 0402 100K OHM 1% 1/16W 0402; Manufacturer: Stackpole Electronics; Manufacturer Part Number: RMCF0402FT100K; Database Table Name: Resistors; Power Rating: 62.5mW; Case Code (Imperial): 0402; Case Code (Metric): 1005; Case/Package: 0402; Category: Chip SMD Resistors; Component Kind: Standard; Contact Plating: Tin,Matte; LCSC Part Number: C25741; Mount: Surface Mount; Part Name: Res-00003; Pricing 1: 1=0.10000, 10=0.01500, 100=0.00610, 1000=0.00; RoHS: Yes; Stock 1: 13703190; Supplier 1: Digi-Key; Supplier Part Number 1: RMCF0402FT100KCT-ND; Library Name: Leo-Home-SQL-Lib.DbLib
R93 R106 R107 = Res-00008: Thick Film 0402 2.8K Ohm 1% 0.063W ±100ppm/°C Molded SMD Paper T/R; Manufacturer: Stackpole Electronics; Manufacturer Part Number: RMCF0402FT2K80; Database Table Name: Resistors; Max Power Dissipation: 63mW; Power Rating: 62.5mW; Resistance: 2.8kR; Case Code (Imperial): 0402; Case Code (Metric): 1005; Case/Package: 0402; Category: Chip SMD Resistors; Component Kind: Standard; ComponentLink1Description: https://www.seielect.com/catalog/SEI-RMCF_RMC; ComponentLink1URL: https://www.seielect.com/catalog/SEI-RMCF_RMC; ComponentLink2Description: https://www.seielect.com/Catalog/SEI-RMCF_RMC; ComponentLink2URL: https://www.seielect.com/Catalog/SEI-RMCF_RMC; ComponentLink3Description: https://www.seielect.com/catalog/SEI-Packagin; ComponentLink3URL: https://www.seielect.com/catalog/SEI-Packagin; Contact Plating: Tin,Matte; Features: Automotive AEC-Q200; Height: 0.016inch; LCSC Part Number: C62741; Lead Free: Lead Free; Length: 0.039inch; Max Operating Temperature: 155°C; Min Operating Temperature: -55°C; Mount: Surface Mount; Number of Terminations: 2; Packaging: Tape and Reel; Part Name: Res-00008; Pricing 1: 1=0.10000, 10=0.01500, 100=0.00610, 1000=0.00; Radiation Hardening: No; Resistor Type: Thick Film; RoHS: Yes; RoHS Compliant: Yes; Series: RMCF; Stock 1: 141882; Supplier 1: Digi-Key; Supplier Part Number 1: RMCF0402FT2K80CT-ND; Temperature Coefficient: 100ppm/°C; Tolerance: 1%; Width: 0.02inch; Library Name: Leo-Home-SQL-Lib.DbLib
R97 R98 = Res-00005: Thick Film  220 OHM 5% 1W 2512; Manufacturer: Stackpole Electronics; Manufacturer Part Number: RMCF2512JT220R; Database Table Name: Resistors; Temperature Coefficient: 200ppm/°C; Case Code (Imperial): 2512; Case Code (Metric): 6432; Case/Package: 2512; Category: Chip SMD Resistors; Component Kind: Standard; ComponentLink1Description: https://datasheet.ciiva.com/15193/getdatashee; ComponentLink1URL: https://datasheet.ciiva.com/15193/getdatashee; Construction: Rectangular; Contact Plating: Tin,Matte; Depth: 3.2mm; Features: Automotive AEC-Q200; Height: 0.028inch; LCSC Part Number: C38808; Lead Free: Lead Free; Length: 0.248inch; Max Operating Temperature: 155°C; Max Power Dissipation: 1W; Military Standard: Not; Min Operating Temperature: -55°C; Mount: Surface Mount; Number of Terminations: 2; Packaging: Tape and Reel; Part Name: Res-00005; Power Rating: 1W; Pricing 1: 1=0.30000, 10=0.25300, 100=0.09890, 1000=0.04; Radiation Hardening: No; Resistor Type: Thick Film; RoHS: Yes; RoHS Compliant: Yes; Series: RMCF; Size Code: 2512; Stock 1: 85; Supplier 1: Digi-Key; Supplier Part Number 1: RMCF2512JT220RCT-ND; Width: 0.126inch; Library Name: Leo-Home-SQL-Lib.DbLib
  R97: Resistance: 220R
R110 = Res-00010: Thick Film 0402 680 Ohm 1% 0.063W(1/16W) ±100ppm/C Pad SMD Automotive T/R; Manufacturer: Stackpole Electronics; Manufacturer Part Number: RMCF0402FT680R; Database Table Name: Resistors; Max Power Dissipation: 63mW; Power Rating: 62.5mW; Temperature Coefficient: 100ppm/°C; Case Code (Imperial): 0402; Case Code (Metric): 1005; Case/Package: 0402; Category: Chip SMD Resistors; Component Kind: Standard; ComponentLink1Description: http://www.seielect.com/Catalog/SEI-RMCF_RMCP; ComponentLink1URL: http://www.seielect.com/Catalog/SEI-RMCF_RMCP; ComponentLink2Description: https://www.seielect.com/catalog/SEI-RMCF_RMC; ComponentLink2URL: https://www.seielect.com/catalog/SEI-RMCF_RMC; ComponentLink3Description: https://www.seielect.com/Catalog/SEI-RMCF_RMC; ComponentLink3URL: https://www.seielect.com/Catalog/SEI-RMCF_RMC; ComponentLink4Description: https://www.seielect.com/catalog/SEI-Packagin; ComponentLink4URL: https://www.seielect.com/catalog/SEI-Packagin; Contact Plating: Tin,Matte; Features: Automotive AEC-Q200; Height: 0.016inch; LCSC Part Number: C25130; Length: 0.039inch; Max Operating Temperature: 155°C; Min Operating Temperature: -55°C; Mount: Surface Mount; Number of Terminations: 2; Package Quantity: 10000; Packaging: Tape and Reel; Part Name: Res-00010; Pricing 1: 1=0.10000, 10=0.01500, 100=0.00610, 1000=0.00; Radiation Hardening: No; Resistor Type: Thick Film; RoHS: Yes; RoHS Compliant: Yes; Series: RMCF; Stock 1: 143190; Supplier 1: Digi-Key; Supplier Part Number 1: RMCF0402FT680RCT-ND; Tolerance: 1%; Width: 0.02inch; Library Name: Leo-Home-SQL-Lib.DbLib
U19 = Mod-0002: Module DC-DC 12VIN 1-OUT 5V 2A 10W 3-Pin SIP Module; Manufacturer: CUI; Database Table Name: Modules; Efficiency: 91%; Isolation: Non-Isolated; Max Input Voltage: 18V; Min Input Voltage: 7V; Number of Outputs: 1; Case/Package: SIP; Category: Board Mount Modules; Component Kind: Standard; ComponentLink1Description: https://datasheet.ciiva.com/2374/v78xx-2000-2; ComponentLink1URL: https://datasheet.ciiva.com/2374/v78xx-2000-2; Current - Output 1: 2A; Features: OTP,SCP; Height: 0.35inch; LCSC Part Number: N/A; Lead Free: Lead Free; Length: 0.69inch; Manufacturer Part Number: V7805-2000R; Max Operating Temperature: 85°C; Max Output Current: 2A; Max Power Dissipation: 10W; Min Operating Temperature: -40°C; Mount: Through Hole; Output Power: 10W; Output Voltage: 5V; Package Quantity: 44; Packaging: Rail/Tube; Part Name: Mod-0002; Pricing 1: 1=9.85000, 5=9.77400, 10=9.70000, 25=9.55040,; RoHS: Yes; RoHS Compliant: Yes; Series: V78-2000; Stock 1: 1153; Supplier 1: Digi-Key; Supplier Part Number 1: 102-2188-ND; Voltage - Output 1: 5V; Width: 0.45inch; Library Name: Leo-Home-SQL-Lib.DbLib
